# Altium schematic sheet [13] PWR1.SchDoc("[13] PWR1")
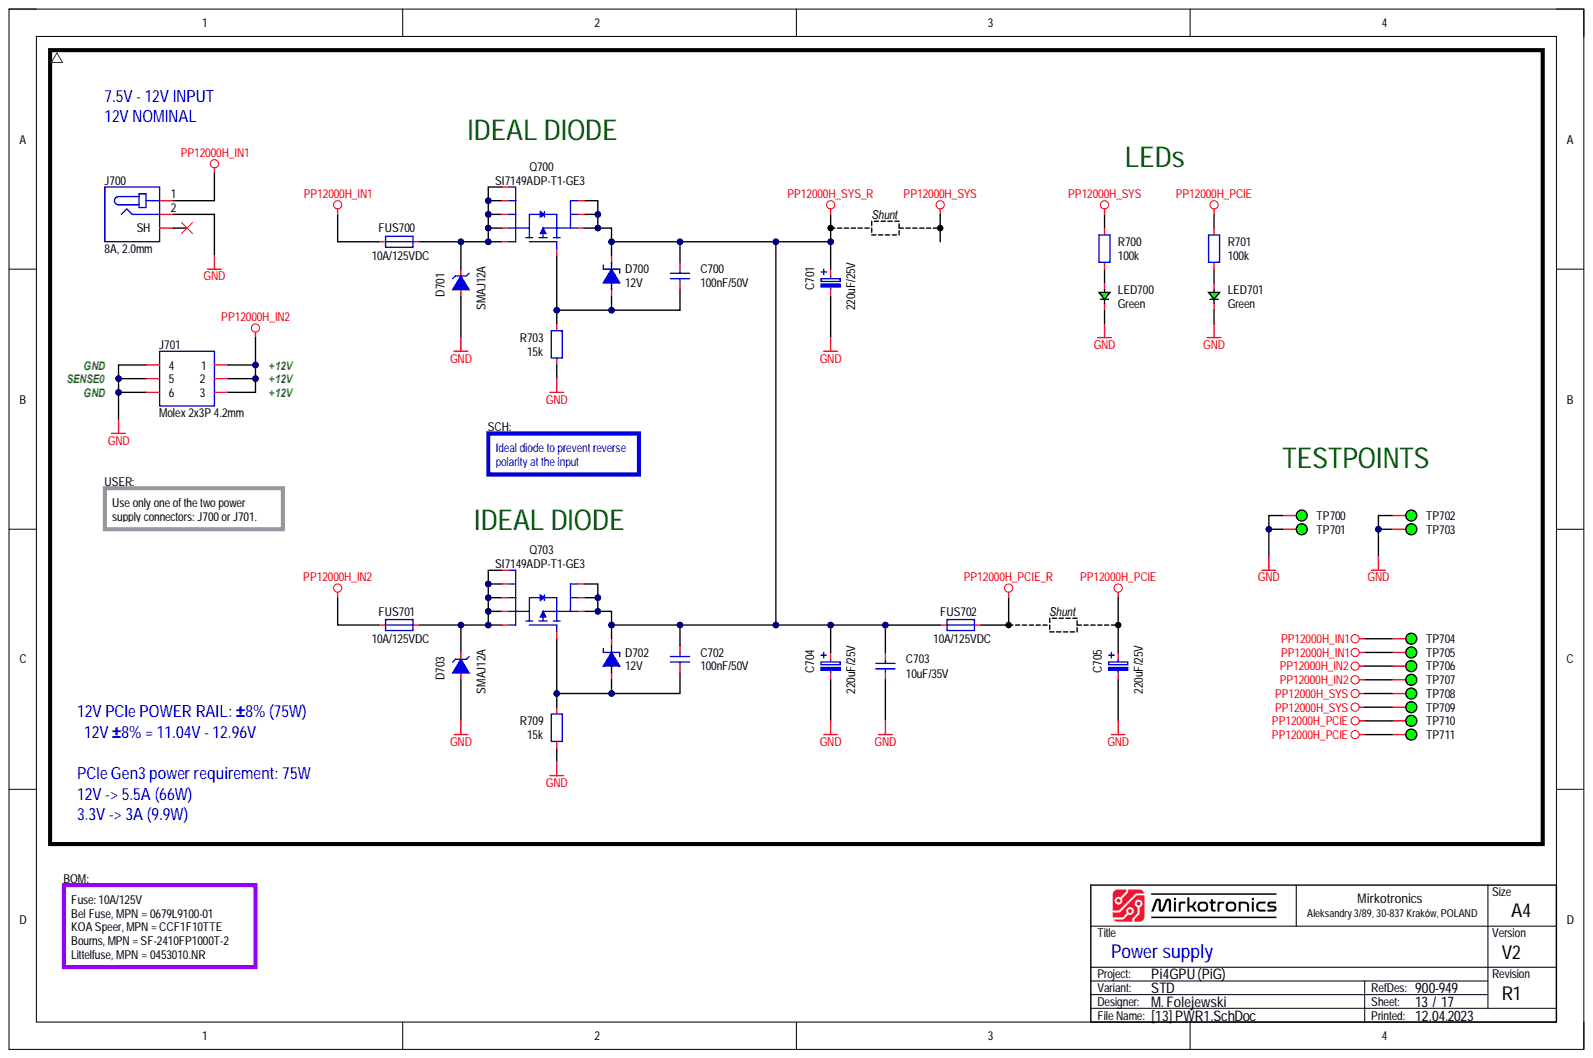
[diagram p0: [13] PWR1.SchDoc]

## Parts
C700  100nF/50V  [CAP_0603_R]
C701  220uF/25V  [CAP_SMD_SIZE_D8]
C702  100nF/50V  [CAP_0603_R]
C703  10uF/35V  [CAP_1206_R]
C704  220uF/25V  [CAP_SMD_SIZE_D8]
C705  220uF/25V  [CAP_SMD_SIZE_D8]
D700  12V  [PKG_SOT23-3_TO236]
D701  SMAJ12A  [DIO_SMA_UNI_R]
D702  12V  [PKG_SOT23-3_TO236]
D703  SMAJ12A  [DIO_SMA_UNI_R]
FUS700  10A/125VDC  [FUS_2410_R]
FUS701  10A/125VDC  [FUS_2410_R]
FUS702  10A/125VDC  [FUS_2410_R]
J700  8A, 2.0mm  [CON_CUI_PJ-063AH]
J701  Molex 2x3P 4.2mm  Manufacturer: Molex  [CON_MLX_039301060]
LED700  Green  [LED_0805_GRN_R]
LED701  Green  [LED_0805_GRN_R]
Q700  MPN: SI7149ADP-T1-GE3  [ICC_SO8_POWERPAK_490X590X112_P127_R]  (hidden comment: PMOS)
Q703  MPN: SI7149ADP-T1-GE3  [ICC_SO8_POWERPAK_490X590X112_P127_R]  (hidden comment: PMOS)
R700  100k  [RES_0603_R]
R701  100k  [RES_0603_R]
R703  15k  [RES_0603_R]
R709  15k  [RES_0603_R]
TP700  ICT  [PCB_TP_ICT_100]  (value not drawn)  (no BOM)
TP701  ICT  [PCB_TP_ICT_100]  (value not drawn)  (no BOM)
TP702  ICT  [PCB_TP_ICT_100]  (value not drawn)  (no BOM)
TP703  ICT  [PCB_TP_ICT_100]  (value not drawn)  (no BOM)
TP704  ICT  [PCB_TP_ICT_100]  (value not drawn)  (no BOM)
TP705  ICT  [PCB_TP_ICT_100]  (value not drawn)  (no BOM)
TP706  ICT  [PCB_TP_ICT_100]  (value not drawn)  (no BOM)
TP707  ICT  [PCB_TP_ICT_100]  (value not drawn)  (no BOM)
TP708  ICT  [PCB_TP_ICT_100]  (value not drawn)  (no BOM)
TP709  ICT  [PCB_TP_ICT_100]  (value not drawn)  (no BOM)
TP710  ICT  [PCB_TP_ICT_100]  (value not drawn)  (no BOM)
TP711  ICT  [PCB_TP_ICT_100]  (value not drawn)  (no BOM)

## Nets
GND: C701.1 C703.1 C704.1 C705.1 D701.2 D703.2 J700.2 J701.4 J701.5 J701.6 LED700.1 LED701.1 R703.1 R709.1 TP700.1 TP701.1 TP702.1 TP703.1
PP12000H_IN1: FUS700.1 J700.1 TP704.1 TP705.1
PP12000H_IN2: FUS701.1 J701.1 J701.2 J701.3 TP706.1 TP707.1
PP12000H_PCIE: C705.2 R701.2 TP710.1 TP711.1
PP12000H_PCIE_R: FUS702.2
PP12000H_SYS: R700.2 TP708.1 TP709.1
PP12000H_SYS_R: C700.2 C701.2 C702.2 C703.2 C704.2 D700.3 D702.3 FUS702.1 Q700.1 Q700.2 Q700.3 Q703.1 Q703.2 Q703.3
NetC700_1: C700.1 D700.1 Q700.4 R703.2
NetC702_1: C702.1 D702.1 Q703.4 R709.2
NetD701_1: D701.1 FUS700.2 Q700.5 Q700.6 Q700.7 Q700.8 Q700.EP
NetD703_1: D703.1 FUS701.2 Q703.5 Q703.6 Q703.7 Q703.8 Q703.EP
NetLED700_2: LED700.2 R700.1
NetLED701_2: LED701.2 R701.1

Not connected: J700 SH

## Library data of the parts
C700 C702 = CAP-0008: Capacitor; MLCC; 100nF; TBD; TBD; TBD; TBD; SMD; 0603 (1608);; Database Table Name: CAPACITOR$; Component Kind: Standard; Component Subtype: MLCC; Component Type: Capacitor; Manufacturer: TBD; Mounting Type: SMD; MPN: TBD; Package (Case): 0603 (1608); Supplier: ?; Supplier 1: LCSC; Supplier Part Number 1: C14663; Value: 100nF/50V; Library Name: MirFol_db_local.DbLib
C701 C704 C705 = CAP_POL_2P_NP: Capacitor; Polarized;; Manufacturer: Panasonic; MPN: EEEFTE221XAP; Component Subtype: Electrolytic; Package (Case): SMD SIZE D8; Component Kind: Standard; Component Type: Capacitor; Mounting Type: SMD; Supplier 1: LCSC; Supplier Part Number 1: C2918361; Value: 220uF/25V; Library Name: MirFol_lib.SchLib
C703 = CAP-0025: Capacitor; MLCC; GENERIC; TBD; TBD; TBD; TBD; SMD; 1206 (3216);; Database Table Name: CAPACITOR$; Component Kind: Standard; Component Subtype: MLCC; Component Type: Capacitor; Manufacturer: GENERIC; Mounting Type: SMD; MPN: GENERIC; Package (Case): 1206 (3216); Supplier: ?; Supplier 1: LCSC; Supplier Part Number 1: C92797; Value: 10uF/35V; Library Name: MirFol_db_local.DbLib
D700 D702 = DIO_ZEN_A_K: Diode; Zener;; Manufacturer: ON Semi; MPN: MMBZ5242BLT3G; Component Kind: Standard; Supplier 1: LCSC; Supplier Part Number 1: C896784; Value: 12V; Library Name: Pineberry_Lib.SchLib
D701 D703 = DIO_TVS_UNI_KA: Diode; Schottky; Unipolar;; Manufacturer: Littelfuse; Component Subtype: TVS Diode; Component Kind: Standard; Component Type: Diode; Mounting Type: SMD; MPN: SMAJ12A; Supplier: ?; Supplier 1: LCSC; Supplier Part Number 1: C713694; Value: SMAJ12A; Library Name: MirFol_lib.SchLib
FUS700-FUS702 = FUS: Fuse; Manufacturer: Bel Fuse; MPN: 0679L9100-01; Component Kind: Standard; Supplier 1: LCSC; Supplier Part Number 1: C2838934; Value: 10A/125VDC; Library Name: MirFol_lib.SchLib
J700 = CON_DC_A1_SH: Connector; DC Barrel Jack;; Manufacturer: CUI Devices; MPN: PJ-063AH; Package (Case): THT; Component Kind: Standard; Component Subtype: DC Barrel Jack; Component Type: Connector; Mounting Type: THT; Supplier 1: Mouser; Supplier Part Number 1: 490-PJ-063AH; Value: 8A, 2.0mm; Library Name: Pineberry_Lib.SchLib
J701 = CON_2X03_COL: Connector; 2 Row;; Component Subtype: Wire to Board; Package (Case): THT; Component Kind: Standard; Component Type: Connector; Mounting Type: THT; MPN: 039-30-1060; Supplier 1: LCSC; Supplier Part Number 1: C54904; Value: Molex 2x3P 4.2mm; Library Name: Pineberry_Lib.SchLib
LED700 LED701 = DIO_LED_GRN: Diode; LED; Green;; Manufacturer: Foshan NationStar Optoelectronics; MPN: NCD0805G1; Component Subtype: LED Diode; Package (Case): 0805 (2012); Component Kind: Standard; Component Type: LED; Mounting Type: SMD; Supplier: LCSC; Supplier 1: LCSC; Supplier Part Number 1: C84260; Value: Green; Library Name: MirFol_lib.SchLib
Q700 Q703 = TRA_PMOS_SSSGDDDD_EP: Transistor; PMOS;; Manufacturer: Vishay; Component Subtype: P-MOSFET; Package (Case): PowerPAK-SO-8; Component Kind: Standard; Component Type: Transistor; Mounting Type: SMD; Supplier 1: LCSC; Supplier Part Number 1: C554002; Value: PMOS; Library Name: Pineberry_Lib.SchLib
R700 R701 = RES-0029: Resistor; Thick Film; 100k; 1%; 100mW; 100PPM; 75V; Standard; SMD; 0603 (1608);; Manufacturer: Yageo Corporation; MPN: TBD; Component Body: 1.60 x 0.80mm; Database Table Name: RESISTOR$; Packaging Type: Tape & Reel; Component Height: 0.45mm; Component Kind: Standard; Component Subtype: Thick Film; Component Type: Resistor; Features: Standard; ID: RES-0029; Mounting Type: SMD; Operating Temperature: -55°C to +155°C; Package (Case): 0603 (1608); Power Rating: 100mW; Resistance: 100k; ROHS Status: RoHS Compliant; Supplier 1: LCSC; Supplier Part Number 1: C25803; TCR: 100PPM; Tolerance: 1%; Value: 100k; Voltage Rating: 75V; Library Name: MirFol_db_local.DbLib
R703 R709 = RES-0043: Resistor; Thick Film; 15k; 1%; 100mW; 100PPM; 75V; Standard; SMD; 0603 (1608);; Manufacturer: Yageo Corporation; MPN: TBD; Database Table Name: RESISTOR$; Component Kind: Standard; Component Subtype: Thick Film; Component Type: Resistor; Mounting Type: SMD; Package (Case): 0603 (1608); Supplier: ?; Supplier 1: LCSC; Supplier Part Number 1: C22809; Value: 15k; Library Name: MirFol_db_local.DbLib
TP700-TP711 = PCB_TP_ICT: Test Point (ICT); Specification: Testpoint (for ICT), SMD pad; Component Type: Testpoint (for ICT); Manufacturer: -; Mounting Type: Non component; MPN: -; Value: ICT; Library Name: MirFol_lib.SchLib
